# Altium schematic sheet TE0876.SchDoc(TE0876)
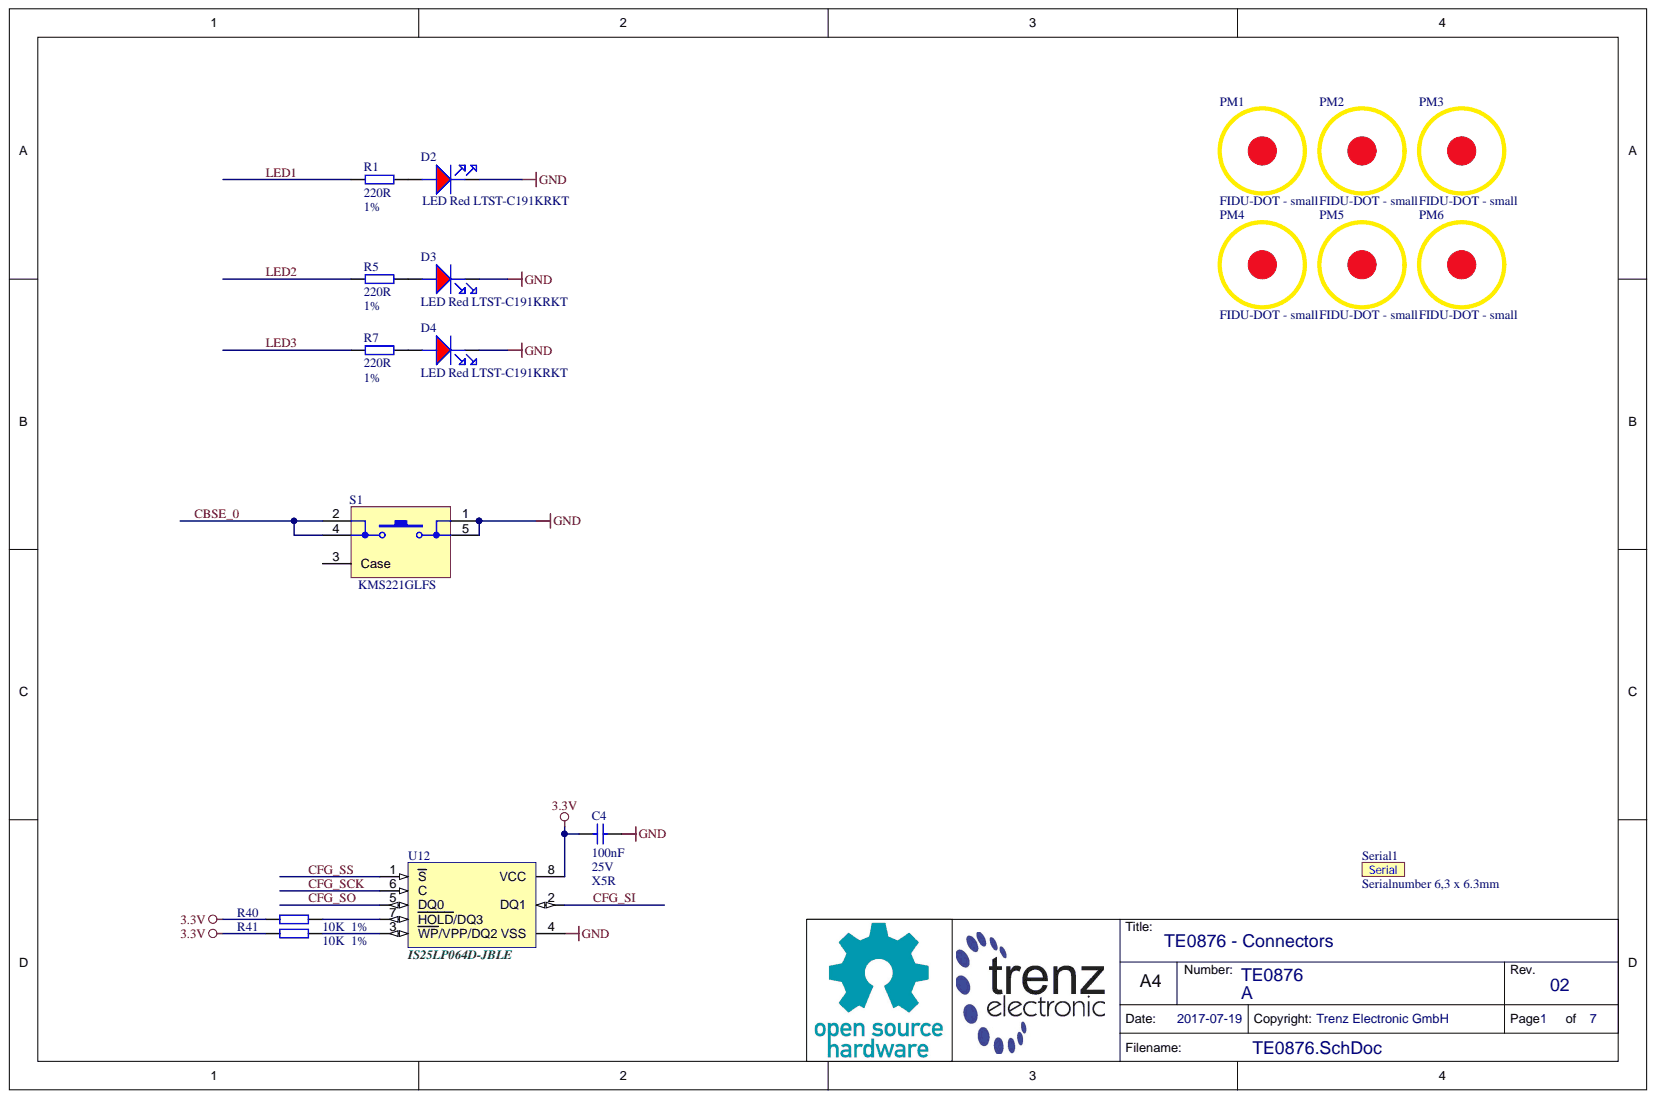
[diagram p0: TE0876.SchDoc]

## Parts
C4  100nF  Voltage: 25V  [CAPC1005X55N]
D2  LED Red LTST-C191KRKT  H-ART: LTST-C191KRKT  Database Table Name: LED  [LEDC1608X55N_RED]
D3  LED Red LTST-C191KRKT  H-ART: LTST-C191KRKT  Database Table Name: LED  [LEDC1608X55N_RED]
D4  LED Red LTST-C191KRKT  H-ART: LTST-C191KRKT  Database Table Name: LED  [LEDC1608X55N_RED]
PM1  FIDU-DOT - small  [FIDU-DOT - small]
PM2  FIDU-DOT - small  [FIDU-DOT - small]
PM3  FIDU-DOT - small  [FIDU-DOT - small]
PM4  FIDU-DOT - small  [FIDU-DOT - small]
PM5  FIDU-DOT - small  [FIDU-DOT - small]
PM6  FIDU-DOT - small  [FIDU-DOT - small]
R1  220R  Tolerance: 1%  [RESC1005X40N]
R5  220R  Tolerance: 1%  [RESC1005X40N]
R7  220R  Tolerance: 1%  [RESC1005X40N]
R40  10K  [RESC1005X40N]
R41  10K  [RESC1005X40N]
S1  KMS221GLFS  [KMS2xxGLFS]
Serial1  Serialnumber 6,3 x 6.3mm  [Serial_6.3x6.3mm]  (no BOM)
U12  IS25LP064D-JBLE  [SOIC127P790X191-8N]

## Nets
3.3V: C4.1 R40.2 R41.2 U12.8(VCC)
GND: C4.2 D2.K D3.K D4.K S1.1 S1.5 U12.4(VSS)
CBSE_0: S1.2 S1.4
CFG_SCK: U12.6(C)
CFG_SI: U12.2(DQ1)
CFG_SO: U12.5(DQ0)
CFG_SS: U12.1(S)
LED1: R1.1
LED2: R5.1
LED3: R7.1
NetD2_A: D2.A R1.2
NetD3_A: D3.A R5.2
NetD4_A: D4.A R7.2
NetR40_1: R40.1 U12.7(HOLD/DQ3)
NetR41_1: R41.1 U12.3(WP/VPP/DQ2)

Not connected: S1 3(Case)

## Library data of the parts
C4 = 22472: KON 100nF 25V X5R SMD ±10%; Value: 100n; Preferred: Yes; Mixed: SMT; Database Table Name: C_1005_0402; Technology: X5R; Library Name: TE.DbLib; Component Kind: Standard; Case: 1005 (0402); AN: 22472
D2-D4 = 28036: LED RED 0603; Mixed: SMT; Library Name: TE.DbLib; Component Kind: Standard; Case: 0603 (1608); AN: 28036; HelpURL: b:\AD\adlib\datasheet\LTST-C191KRKT\
PM1-PM6 = 22905: FIDU-DOT - SMALL 1mm; Mixed: FIDU; Database Table Name: PCB; Library Name: TE.DbLib; Component Kind: Standard; AN: 22905
R1 R5 R7 = 22767: WID SMD 220R 1% 0,063W; Value: 220; Preferred: Yes; Mixed: SMT; Database Table Name: R_1005_0402; Technology: TK100; Library Name: TE.DbLib; Component Kind: Standard; Case: 1005 (0402); AN: 22767
R40 R41 = 22750: WID SMD 10K 1% 0,063W; Preferred: Yes; Mixed: SMT; Database Table Name: R_1005_0402; Value: 10K; Tolerance: 1%; Technology: TK100; Library Name: TE.DbLib; Component Kind: Standard; Case: 1005 (0402); AN: 22750
S1 = 26229: KMS221GLFS - SWITCH, SPST, 0.05A, 32VDC, SMD; Mixed: SMT; Database Table Name: PB_SW; Library Name: TE.DbLib; H-ART: KMS221GLFS; Component Kind: Standard; AN: 26229; HelpURL: B:\AD\adlib\datasheet\KMS
Serial1 = 26753: Database Table Name: PCB; Library Name: TE.DbLib; Description: Serialnumber 6,3 x 6.3mm; AN: 26753
U12 = 25582: 3V 64Mb QPI/QSPI Flash, 8-pin SOP 208Mil, RoHS; Mixed: SMT; AN: 30166; Library Name: TE.DbLib; H-ART: IS25LP064D-JBLE; Database Table Name: FLASH; Component Kind: Standard; Case: SO8W; HelpURL: b:\AD\adlib\datasheet\ISSI\IS25xP064D\
